# Altium schematic sheet Converter.SchDoc(Converter)
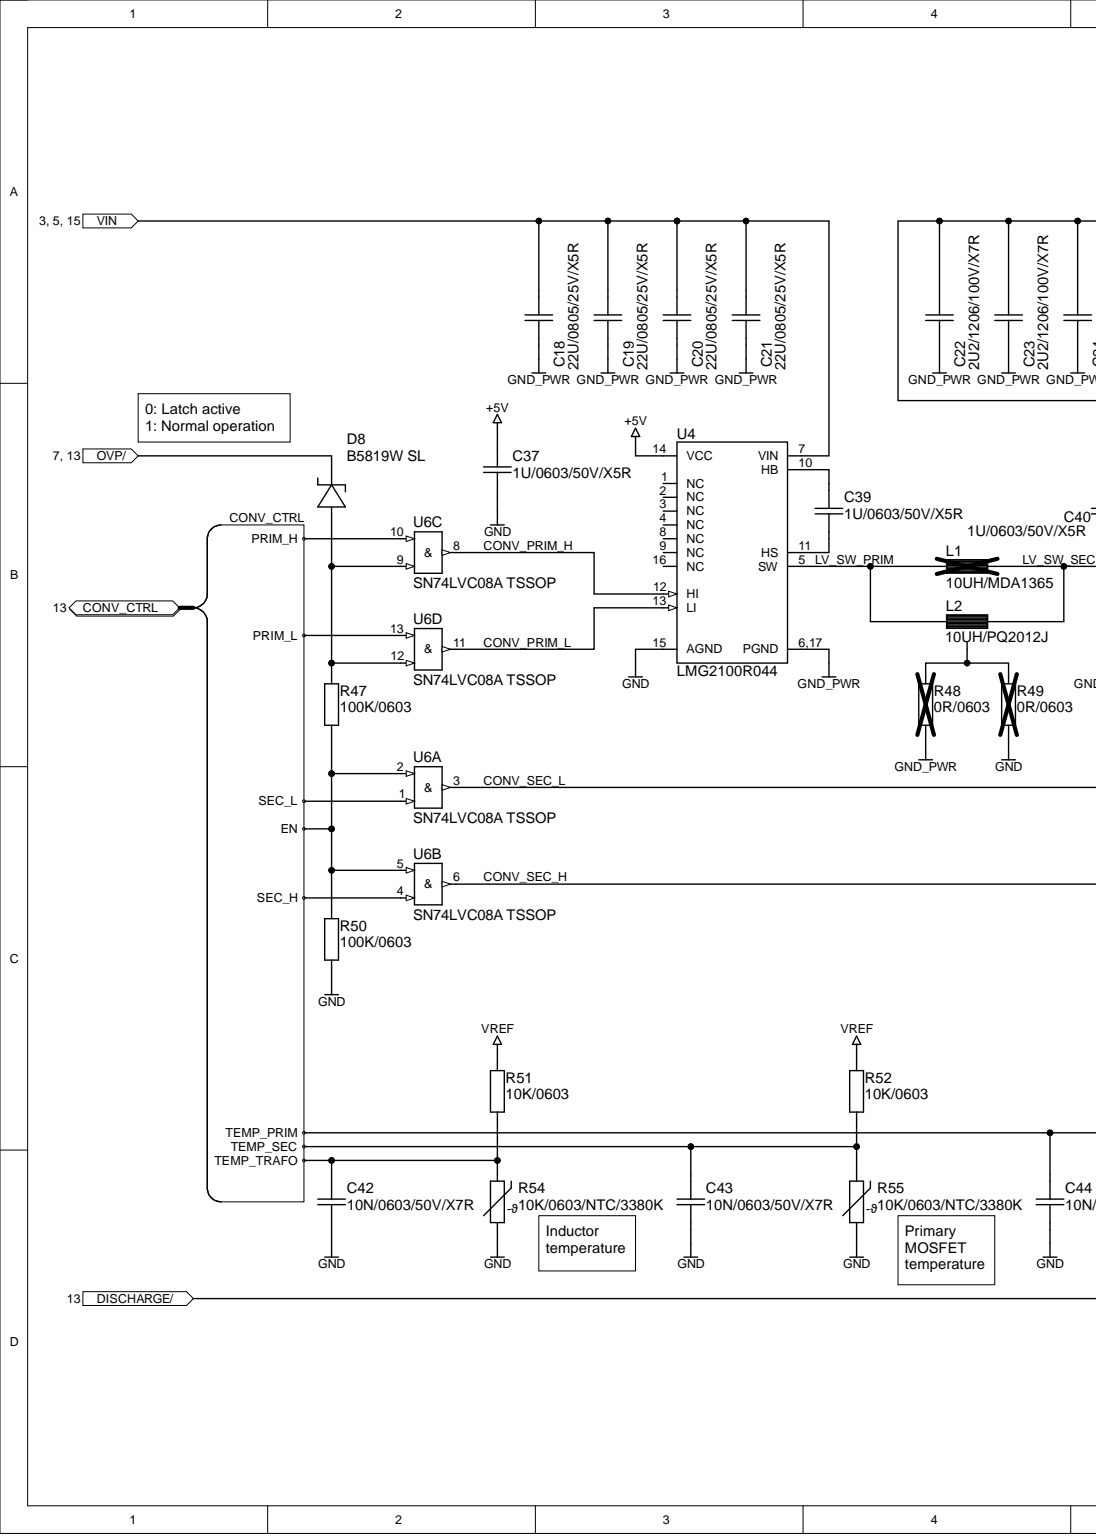
[diagram p0: Converter.SchDoc, left]
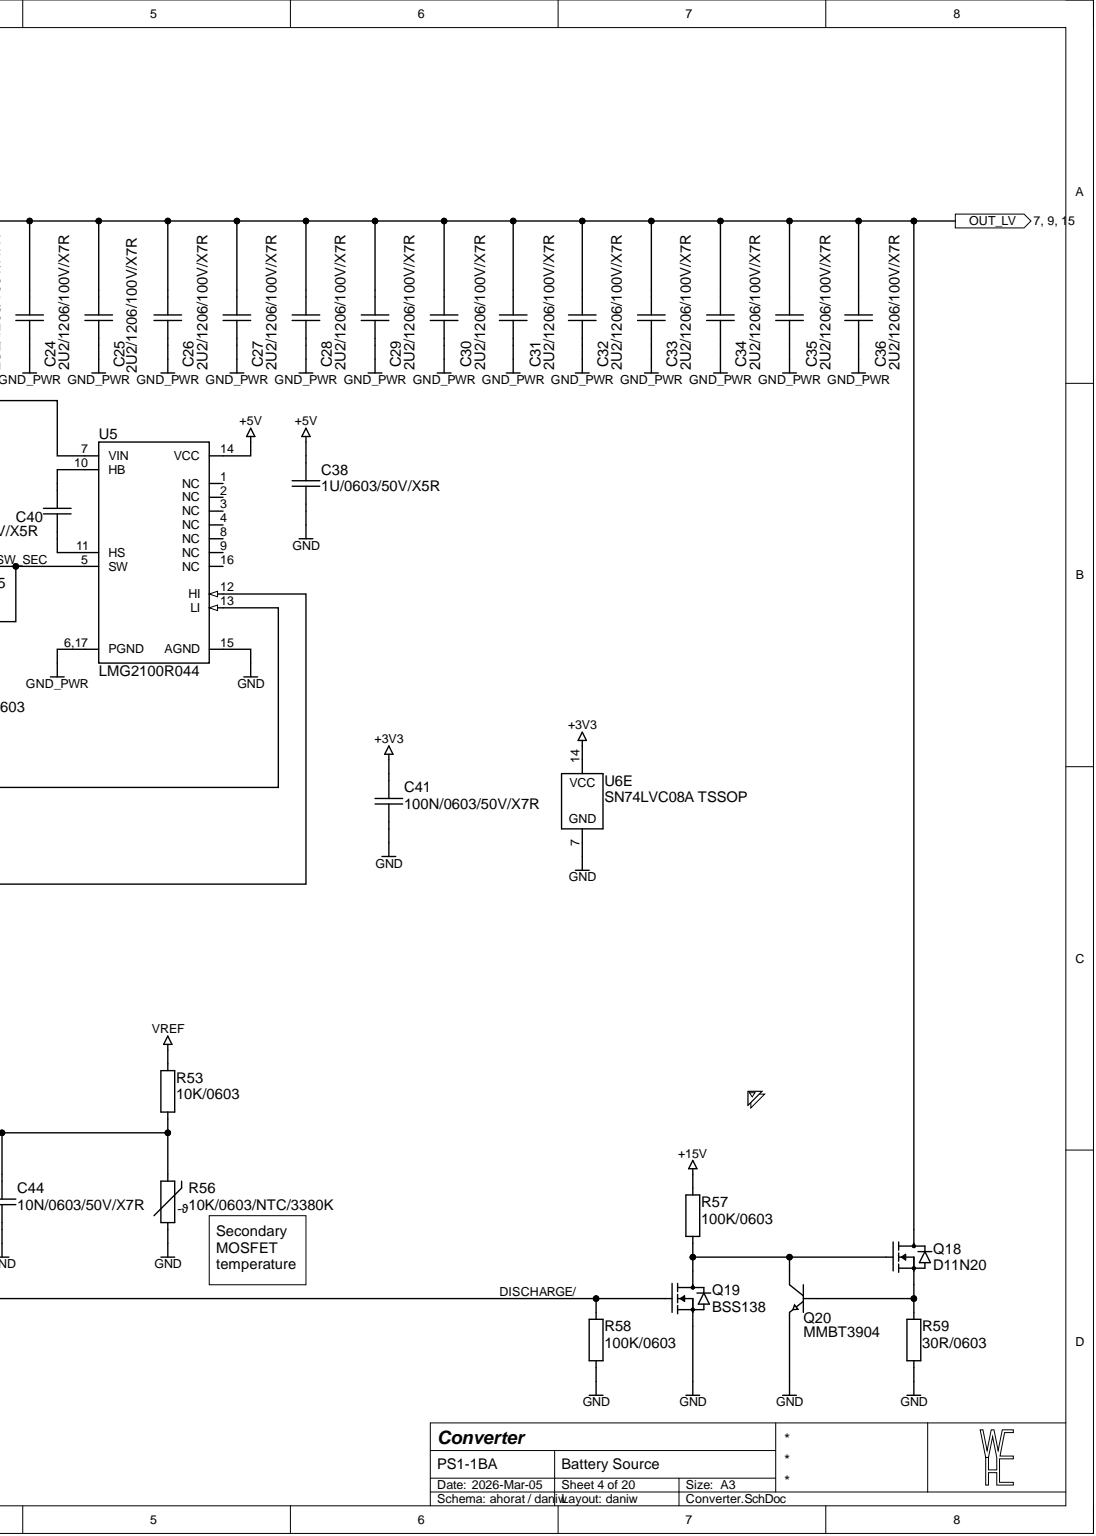
[diagram p1: Converter.SchDoc, right]

## Parts
C18  22U/0805/25V/X5R  [CAP0805_X7R]
C19  22U/0805/25V/X5R  [CAP0805_X7R]
C20  22U/0805/25V/X5R  [CAP0805_X7R]
C21  22U/0805/25V/X5R  [CAP0805_X7R]
C22  2U2/1206/100V/X7R  [CAP1206-X7R]
C23  2U2/1206/100V/X7R  [CAP1206-X7R]
C24  2U2/1206/100V/X7R  [CAP1206-X7R]
C25  2U2/1206/100V/X7R  [CAP1206-X7R]
C26  2U2/1206/100V/X7R  [CAP1206-X7R]
C27  2U2/1206/100V/X7R  [CAP1206-X7R]
C28  2U2/1206/100V/X7R  [CAP1206-X7R]
C29  2U2/1206/100V/X7R  [CAP1206-X7R]
C30  2U2/1206/100V/X7R  [CAP1206-X7R]
C31  2U2/1206/100V/X7R  [CAP1206-X7R]
C32  2U2/1206/100V/X7R  [CAP1206-X7R]
C33  2U2/1206/100V/X7R  [CAP1206-X7R]
C34  2U2/1206/100V/X7R  [CAP1206-X7R]
C35  2U2/1206/100V/X7R  [CAP1206-X7R]
C36  2U2/1206/100V/X7R  [CAP1206-X7R]
C37  1U/0603/50V/X5R  [CAP0603_X7R]
C38  1U/0603/50V/X5R  [CAP0603_X7R]
C39  1U/0603/50V/X5R  [CAP0603_X7R]
C40  1U/0603/50V/X5R  [CAP0603_X7R]
C41  100N/0603/50V/X7R  [CAP0603_X7R]
C42  10N/0603/50V/X7R  [CAP0603_X7R]
C43  10N/0603/50V/X7R  [CAP0603_X7R]
C44  10N/0603/50V/X7R  [CAP0603_X7R]
D8  B5819W SL  [SOD3718X125]
L1  10UH/MDA1365  [INDM140X128X65]
L2  10UH/PQ2012J  [IND_PQ2015J]
Q18  D11N20  [TO228P990X229-3]
Q19  BSS138  [SOT2395P280X125-3-SE]
Q20  MMBT3904  [SOT2395P280X125-3-SE]
R47  100K/0603  [R0603-0.40-WW]
R48  0R/0603  [R0603-0.40-WW]
R49  0R/0603  [R0603-0.40-WW]
R50  100K/0603  [R0603-0.40-WW]
R51  10K/0603  [R0603-0.40-WW]
R52  10K/0603  [R0603-0.40-WW]
R53  10K/0603  [R0603-0.40-WW]
R54  10K/0603/NTC/3380K  [R0603-0.40-WW]
R55  10K/0603/NTC/3380K  [R0603-0.40-WW]
R56  10K/0603/NTC/3380K  [R0603-0.40-WW]
R57  100K/0603  [R0603-0.40-WW]
R58  100K/0603  [R0603-0.40-WW]
R59  30R/0603  [R0603-0.40-WW]
U4  LMG2100R044  [QFN050P450X550X085-16-TI-VQFN-FCRLF]
U5  LMG2100R044  [QFN050P450X550X085-16-TI-VQFN-FCRLF]
U6  SN74LVC08A TSSOP  [SOP065P640X120-14NW]  (parts A,B,C,D,E)

## Ports
CONV_CTRL, CONV_CTRL.EN, CONV_CTRL.PRIM_H, CONV_CTRL.PRIM_L, CONV_CTRL.SEC_H, CONV_CTRL.SEC_L, CONV_CTRL.TEMP_PRIM, CONV_CTRL.TEMP_SEC, CONV_CTRL.TEMP_TRAFO, DISCHARGE/, OUT_LV, OVP/, VIN

## Nets
+3V3: C41.1 U6.14
+5V: C37.1 C38.1 U4.14(VCC) U5.14(VCC)
+15V: R57.1
GND: C37.2 C38.2 C41.2 C42.2 C43.2 C44.2 Q19.2 Q20.2 R49.2 R50.2 R54.2 R55.2 R56.2 R58.2 R59.2 U4.15(AGND) U5.15(AGND) U6.7
GND_PWR: C18.2 C19.2 C20.2 C21.2 C22.2 C23.2 C24.2 C25.2 C26.2 C27.2 C28.2 C29.2 C30.2 C31.2 C32.2 C33.2 C34.2 C35.2 C36.2 R48.2 U4.6,17(PGND) U5.6,17(PGND)
VREF: R51.1 R52.1 R53.1
CONV_PRIM_H: U4.12(HI) U6.8(&)
CONV_PRIM_L: U4.13(LI) U6.11(&)
CONV_SEC_H: U5.12(HI) U6.6(&)
CONV_SEC_L: U5.13(LI) U6.3(&)
DISCHARGE/: Q19.1 R58.1 ; port DISCHARGE/
LV_SW_PRIM: L1.1 L2.1 U4.5(SW)
LV_SW_SEC: L1.2 L2.2 U5.5(SW)
OUT_LV: C22.1 C23.1 C24.1 C25.1 C26.1 C27.1 C28.1 C29.1 C30.1 C31.1 C32.1 C33.1 C34.1 C35.1 C36.1 Q18.3 U5.7(VIN) ; port OUT_LV
OVP/: D8.1 ; port OVP/
VIN: C18.1 C19.1 C20.1 C21.1 U4.7(VIN) ; port VIN
NetC39_1: C39.1 U4.10(HB)
NetC39_2: C39.2 U4.11(HS)
NetC40_1: C40.1 U5.10(HB)
NetC40_2: C40.2 U5.11(HS)
NetC42_1: C42.1 R51.2 R54.1 ; port CONV_CTRL
NetC43_1: C43.1 R52.2 R55.1 ; port CONV_CTRL
NetC44_1: C44.1 R53.2 R56.1 ; port CONV_CTRL
NetD8_2: D8.2 R47.2 U6.9 U6.12
NetL2_3: L2.3 R48.1 R49.1
NetQ18_1: Q18.1 Q19.3 Q20.3 R57.2
NetQ18_2: Q18.2 Q20.1 R59.1
NetR47_1: R47.1 R50.1 U6.2 U6.5 ; port CONV_CTRL
NetU4_1: U4.1(NC)
NetU4_2: U4.2(NC)
NetU4_3: U4.3(NC)
NetU4_4: U4.4(NC)
NetU4_8: U4.8(NC)
NetU4_9: U4.9(NC)
NetU4_16: U4.16(NC)
NetU5_1: U5.1(NC)
NetU5_2: U5.2(NC)
NetU5_3: U5.3(NC)
NetU5_4: U5.4(NC)
NetU5_8: U5.8(NC)
NetU5_9: U5.9(NC)
NetU5_16: U5.16(NC)
NetU6_1: U6.1 ; port CONV_CTRL
NetU6_4: U6.4 ; port CONV_CTRL
NetU6_10: U6.10 ; port CONV_CTRL
NetU6_13: U6.13 ; port CONV_CTRL

## Library data of the parts
C18-C21 = 22U/0805/25V/X5R: CAP SM 0805 22uF 25V X5R; Manufacturer: GENERIC; Manufacturer 1: Samsung Electro-Mechanics; Manufacturer Part Number 1: CL21A226MAYNNNE; Absolute Value: 2.2E-5; Database Table Name: C$; Eurocircuits GPN: GPN0805106-25; Component Kind: Standard; HelpURL: https://mm.digikey.com/Volume0/opasdata/d220001/medias/docus/339/CL21A226MAYNNNE_Spec.pdf; JLC Type: Basic; Manufacturer Part Number 3: CL21A226MAQNNNE; Package: 0805; Part Number: 22U/0805/25V/X5R; Supplier 1: Digi-Key; Supplier 3: LCSC; Supplier Part Number 1: 1276-CL21A226MAYNNNECT-ND; Supplier Part Number 3: C45783; Value: 22uF; Library Name: daniw_altium_lib.DbLib
C22-C36 = 2U2/1206/100V/X7R: CAP SM 1206 2.2uF 100V X7R; Manufacturer: GENERIC; Manufacturer 1: YAGEO; Manufacturer Part Number 1: CC1206KKX7R0BB225; Absolute Value: 2.2E-6; Database Table Name: C$; Component Kind: Standard; HelpURL: https://www.yageogroup.com/content/datasheet/asset/file/UPY-GPHC_X7R_6_3V-TO-250V; JLC Type: Extended; Manufacturer Part Number 3: CC1206KKX7R0BB225; Package: 1206; Part Number: 2U2/1206/100V/X7R; Supplier 1: Digi-Key; Supplier 3: LCSC; Supplier Part Number 1: 311-3450-1-ND; Supplier Part Number 3: C577211; Value: 2.2uF; Library Name: daniw_altium_lib.DbLib
C37-C40 = 1U/0603/50V/X5R: CAP SM 0603 1.0uF 50V X5R; Manufacturer: GENERIC; Manufacturer 1: Samsung Electro-Mechanics; Manufacturer Part Number 1: CL10A105KB8NNNC; Absolute Value: 1E-6; Database Table Name: C$; Eurocircuits GPN: GPC0603105-X5R; Component Kind: Standard; HelpURL: https://mm.digikey.com/Volume0/opasdata/d220001/medias/docus/658/CL10A105KB8NNNC_Spec.pdf; JLC Type: Basic; Manufacturer Part Number 3: CL10A105KB8NNNC; Package: 0603; Part Number: 1U/0603/50V/X5R; Supplier 1: Digi-Key; Supplier 3: LCSC; Supplier Part Number 1: 1276-1860-1-ND; Supplier Part Number 3: C15849; Value: 1.0uF; Library Name: daniw_altium_lib.DbLib
C41 = 100N/0603/50V/X7R: CAP SM 0603 100nF 50V X7R; Manufacturer: GENERIC; Manufacturer 1: YAGEO; Manufacturer Part Number 1: CC0603KPX7R9BB104; Absolute Value: 1E-7; Database Table Name: C$; Eurocircuits GPN: GPC0603104; Component Kind: Standard; HelpURL: https://www.yageo.com/upload/media/product/app/datasheet/mlcc/upy-gphc_x7r_6_3v-to-250v.pdf; JLC Type: Basic; Manufacturer 2: YAGEO; Manufacturer Part Number 2: CC0603MRX7R9BB104; Manufacturer Part Number 3: CC0603KRX7R9BB104; Package: 0603; Part Number: 100N/0603/50V/X7R; Supplier 1: Digi-Key; Supplier 2: Digi-Key; Supplier 3: LCSC; Supplier Part Number 1: 311-3567-1-ND; Supplier Part Number 2: 311-4110-1-ND; Supplier Part Number 3: C14663; Value: 100nF; Library Name: daniw_altium_lib.DbLib
C42-C44 = 10N/0603/50V/X7R: CAP SM 0603 10nF 50V X7R; Manufacturer: GENERIC; Manufacturer 1: YAGEO; Manufacturer Part Number 1: CC0603KPX7R9BB103; Absolute Value: 1E-8; Database Table Name: C$; Eurocircuits GPN: GPC0603103; Component Kind: Standard; HelpURL: https://www.yageo.com/upload/media/product/app/datasheet/mlcc/upy-gphc_x7r_6_3v-to-250v.pdf; Manufacturer 2: YAGEO; Manufacturer Part Number 2: CC0603KRX7R9BB103; Manufacturer Part Number 3: CC0603KRX7R9BB103; Package: 0603; Part Number: 10N/0603/50V/X7R; Supplier 1: Digi-Key; Supplier 2: Digi-Key; Supplier 3: LCSC; Supplier Part Number 1: 311-1572-1-ND; Supplier Part Number 2: 311-1085-1-ND; Supplier Part Number 3: C100042; Value: 10nF; Library Name: daniw_altium_lib.DbLib
D8 = B5819W SL: 40V 600mV@1A 1A SOD-123 Schottky Barrier Diodes; Manufacturer: GENERIC; Manufacturer 1: SMC Diode Solutions; Database Table Name: D$; Absolute Value: 40V; Component Kind: Standard; HelpURL: https://datasheet.lcsc.com/lcsc/2304140030_Jiangsu-Changjing-Electronics-Technology-Co---Ltd--B5819W-SL_C8598.pdf; JLC Type: Basic; Manufacturer 3: Jiangsu Changjing Electronics Technology Co., Ltd.; Manufacturer Part Number 1: B5819WSL; Manufacturer Part Number 3: B5819W SL; Package: SOD-123; Part Number: B5819W SL; Supplier 1: Digikey; Supplier 3: LCSC; Supplier Part Number 1: 1655-B5819WSLCT-ND; Supplier Part Number 3: C8598; Value: B5819W SL; Library Name: daniw_altium_lib.DbLib
L1 = 10UH/MDA1365: Fixed Ind 10uH 11A 15.8mOhm SMD MDA1365; Manufacturer: Koherelec; Absolute Value: 1E-5; Database Table Name: L$; Component Kind: Standard; HelpURL: http://www.kohergroup.com/template/default/pdf/MDA1365.pdf; JLC Type: Extended; Manufacturer 3: KOHERelec; Manufacturer Part Number 3: MDA1365-100M; Package: 1365; Part Number: 10UH/MDA1365; Supplier 3: LCSC; Supplier Part Number 3: C2847583; Value: 10UH; Library Name: daniw_altium_lib.DbLib
L2 = 10UH/PQ2012J: Fixed Ind 10uH 14A 4.8mOhm SMD; Manufacturer: XR; Absolute Value: 1E-5; Database Table Name: L$; Component Kind: Standard; HelpURL: https://www.lcsc.com/datasheet/C48391639.pdf; JLC Type: Extended; Manufacturer 3: XR; Manufacturer Part Number 3: XRPQ2012J-100M; Package: PQ2012J; Part Number: 10UH/PQ2012J; Supplier 3: LCSC; Supplier Part Number 3: C48391639; Value: 10UH; Library Name: daniw_altium_lib.DbLib
Q18 = D11N20: N-Channel MOSFET 200V 11A; Manufacturer: Jingdao Microelectronics; Database Table Name: T$; Component Kind: Standard; HelpURL: https://www.lcsc.com/datasheet/C2935478.pdf; JLC Type: Extended; Manufacturer 3: Jingdao Microelectronics; Manufacturer Part Number 3: D11N20; Package: TO-252AA; Part Number: D11N20; Supplier 3: LCSC; Supplier Part Number 3: C2935478; Library Name: daniw_altium_lib.DbLib
Q19 = BSS138: N-Kanal 50 V 220mA (Ta) 350mW (Ta) Oberflächenmontage SOT-23; Manufacturer: GENERIC; Manufacturer 1: ANBON SEMICONDUCTOR (INT'L) LIMITED; Database Table Name: T$; Component Kind: Standard; HelpURL: https://mm.digikey.com/Volume0/opasdata/d220001/medias/docus/3037/BSS138.pdf; JLC Type: Extended; Manufacturer 2: FOSAN; Manufacturer 3: FOSAN; Manufacturer Part Number 1: BSS138; Manufacturer Part Number 2: BSS138; Manufacturer Part Number 3: BSS138; Package: SOT23; Part Number: BSS138; Supplier 1: Digi-Key; Supplier 2: LCSC; Supplier 3: LCSC; Supplier Part Number 1: 4530-BSS138CT-ND; Supplier Part Number 2: C2926186; Supplier Part Number 3: C2926186; Library Name: daniw_altium_lib.DbLib
Q20 = MMBT3904: MMBT3904 40V, 0.2A NPN BJT transistor; Manufacturer: GENERIC; Manufacturer 1: onsemi; Manufacturer Part Number 1: MMBT3904LT1G; Database Table Name: T$; Component Kind: Standard; HelpURL: https://onsemi.com/pdf/datasheet/mmbt3904lt1-d.pdf; JLC Type: Basic; Manufacturer 2: MCC (Micro Commercial Components); Manufacturer 3: JSCJ; Manufacturer Part Number 2: MMBT3904-TP; Manufacturer Part Number 3: MMBT3904(RANGE:100-300); Package: SOT23; Part Number: MMBT3904; Supplier 1: Digi-Key; Supplier 2: Digikey; Supplier 3: LCSC; Supplier Part Number 1: MMBT3904LT1GOSCT-ND; Supplier Part Number 2: MMBT3904TPMSCT-ND; Supplier Part Number 3: C20526; Library Name: daniw_altium_lib.DbLib
R47 R50 R57 R58 = 100K/0603: RES SM 0603 100K; Manufacturer: GENERIC; Manufacturer 1: YAGEO; Manufacturer Part Number 1: RC0603FR-13100KL; Absolute Value: 100000; Database Table Name: R$; Eurocircuits GPN: GPR0603100K; Component Kind: Standard; HelpURL: https://www.yageogroup.com/content/datasheet/asset/file/PYU-RC_GROUP_51_ROHS_L; JLC Type: Basic; Manufacturer 2: YAGEO; Manufacturer 3: UNI-ROYAL(Uniroyal Elec); Manufacturer Part Number 2: RC0603FR-10100KL; Manufacturer Part Number 3: 0603WAF1003T5E; Package: 0603; Part Number: 100K/0603; Supplier 1: Digi-Key; Supplier 2: Digi-Key; Supplier 3: LCSC; Supplier Part Number 1: 13-RC0603FR-13100KLCT-ND; Supplier Part Number 2: 13-RC0603FR-10100KLCT-ND; Supplier Part Number 3: C25803; Value: 100K; Library Name: daniw_altium_lib.DbLib
R48 R49 = 0R/0603: RES SM 0603 0R; Manufacturer: GENERIC; Manufacturer 1: YAGEO; Manufacturer Part Number 1: RC0603FR-070RL; Absolute Value: 0; Database Table Name: R$; Eurocircuits GPN: GPR06030R; Component Kind: Standard; HelpURL: https://www.yageogroup.com/content/datasheet/asset/file/PYU-RC_GROUP_51_ROHS_L; JLC Type: Basic; Manufacturer 2: YAGEO; Manufacturer 3: UNI-ROYAL(Uniroyal Elec); Manufacturer Part Number 2: RC0603FR-130RL; Manufacturer Part Number 3: 0603WAF0000T5E; Package: 0603; Part Number: 0R/0603; Supplier 1: Digi-Key; Supplier 2: Digi-Key; Supplier 3: LCSC; Supplier Part Number 1: 311-0.0HRCT-ND; Supplier Part Number 2: 13-RC0603FR-130RLCT-ND; Supplier Part Number 3: C21189; Value: 0R; Library Name: daniw_altium_lib.DbLib
R51-R53 = 10K/0603: RES SM 0603 10K; Manufacturer: GENERIC; Manufacturer 1: YAGEO; Manufacturer Part Number 1: RC0603FR-1310KL; Absolute Value: 10000; Database Table Name: R$; Eurocircuits GPN: GPR060310K; Component Kind: Standard; HelpURL: https://www.yageogroup.com/content/datasheet/asset/file/PYU-RC_GROUP_51_ROHS_L; JLC Type: Basic; Manufacturer 2: YAGEO; Manufacturer 3: UNI-ROYAL(Uniroyal Elec); Manufacturer Part Number 2: RC0603FR-1010KL; Manufacturer Part Number 3: 0603WAF1002T5E; Package: 0603; Part Number: 10K/0603; Supplier 1: Digi-Key; Supplier 2: Digi-Key; Supplier 3: LCSC; Supplier Part Number 1: 13-RC0603FR-1310KLCT-ND; Supplier Part Number 2: 13-RC0603FR-1010KLCT-ND; Supplier Part Number 3: C25804; Value: 10K; Library Name: daniw_altium_lib.DbLib
R54-R56 = 10K/0603/NTC/3380K: RES NTC SM 0603 10K; Manufacturer: GENERIC; Manufacturer 1: Murata; Manufacturer Part Number 1: NCU18XH103E60RB; Absolute Value: 10000; Database Table Name: R$; Eurocircuits GPN: GPR060310K; Component Kind: Standard; HelpURL: https://www.murata.com/en-us/api/pdfdownloadapi?cate=luNTCforTempeSenso&partno=NCU18XH103E60RB; JLC Type: Extended; Manufacturer 3: Sunlord; Manufacturer Part Number 3: SDNT1608X103F3380FTF; Package: 0603; Part Number: 10K/0603/NTC/3380K; Supplier 1: Digi-Key; Supplier 3: LCSC; Supplier Part Number 1: 490-18164-1-ND; Supplier Part Number 3: C316397; Value: 10K; Library Name: daniw_altium_lib.DbLib
R59 = 30R/0603: RES SM 0603 30R; Manufacturer: GENERIC; Manufacturer 1: YAGEO; Manufacturer Part Number 1: RC0603FR-1330RL; Absolute Value: 30; Database Table Name: R$; Eurocircuits GPN: GPR060330R; Component Kind: Standard; HelpURL: https://www.yageogroup.com/content/datasheet/asset/file/PYU-RC_GROUP_51_ROHS_L; JLC Type: Extended; Manufacturer 2: YAGEO; Manufacturer 3: YAGEO; Manufacturer Part Number 2: RC0603FR-0730RL; Manufacturer Part Number 3: RC0603FR-0730RL; Package: 0603; Part Number: 30R/0603; Supplier 1: Digi-Key; Supplier 2: Digi-Key; Supplier 3: LCSC; Supplier Part Number 1: 13-RC0603FR-1330RLCT-ND; Supplier Part Number 2: 311-30.0HRCT-ND; Supplier Part Number 3: C128060; Value: 30R; Library Name: daniw_altium_lib.DbLib
U4 U5 = LMG2100R044: LMG2100R044 100V, 35A GaN Half-Bridge Power Stage; Manufacturer: Texas Instruments; Manufacturer Part Number 1: LMG2100R044RARR; Database Table Name: Integrated$; Component Kind: Standard; HelpURL: https://www.ti.com/lit/ds/symlink/lmg2100r044.pdf; JLC Type: Extended; Manufacturer 1: Texas Instruments; Manufacturer 3: TI; Manufacturer Part Number 3: LMG2100R044RARR; Package: QFN-17; Part Number: LMG2100R044; Supplier 1: Digi-Key; Supplier 3: LCSC; Supplier Part Number 1: 296-LMG2100R044RARRCT-ND; Supplier Part Number 3: C22453052; Library Name: daniw_altium_lib.DbLib
U6 = SN74LVC08A TSSOP: SN74LVC08A Quadruple 2-Input Positive-AND Gates; Manufacturer: TI; Manufacturer 1: Texas Instruments; Manufacturer Part Number 1: SN74LVC08APWR; Database Table Name: Logic$; Component Kind: Standard; HelpURL: https://www.ti.com/lit/ds/symlink/sn74lvc08a.pdf; JLC Type: Extended; Manufacturer 3: TI; Manufacturer Part Number 3: SN74LVC08APWR; Package: TSSOP; Part Number: SN74LVC08A TSSOP; Supplier 1: Digi-Key; Supplier 3: LCSC; Supplier Part Number 1: 296-1221-1-ND; Supplier Part Number 3: C465737; Library Name: daniw_altium_lib.DbLib
